# Altium schematic sheet Sheet1.SchDoc
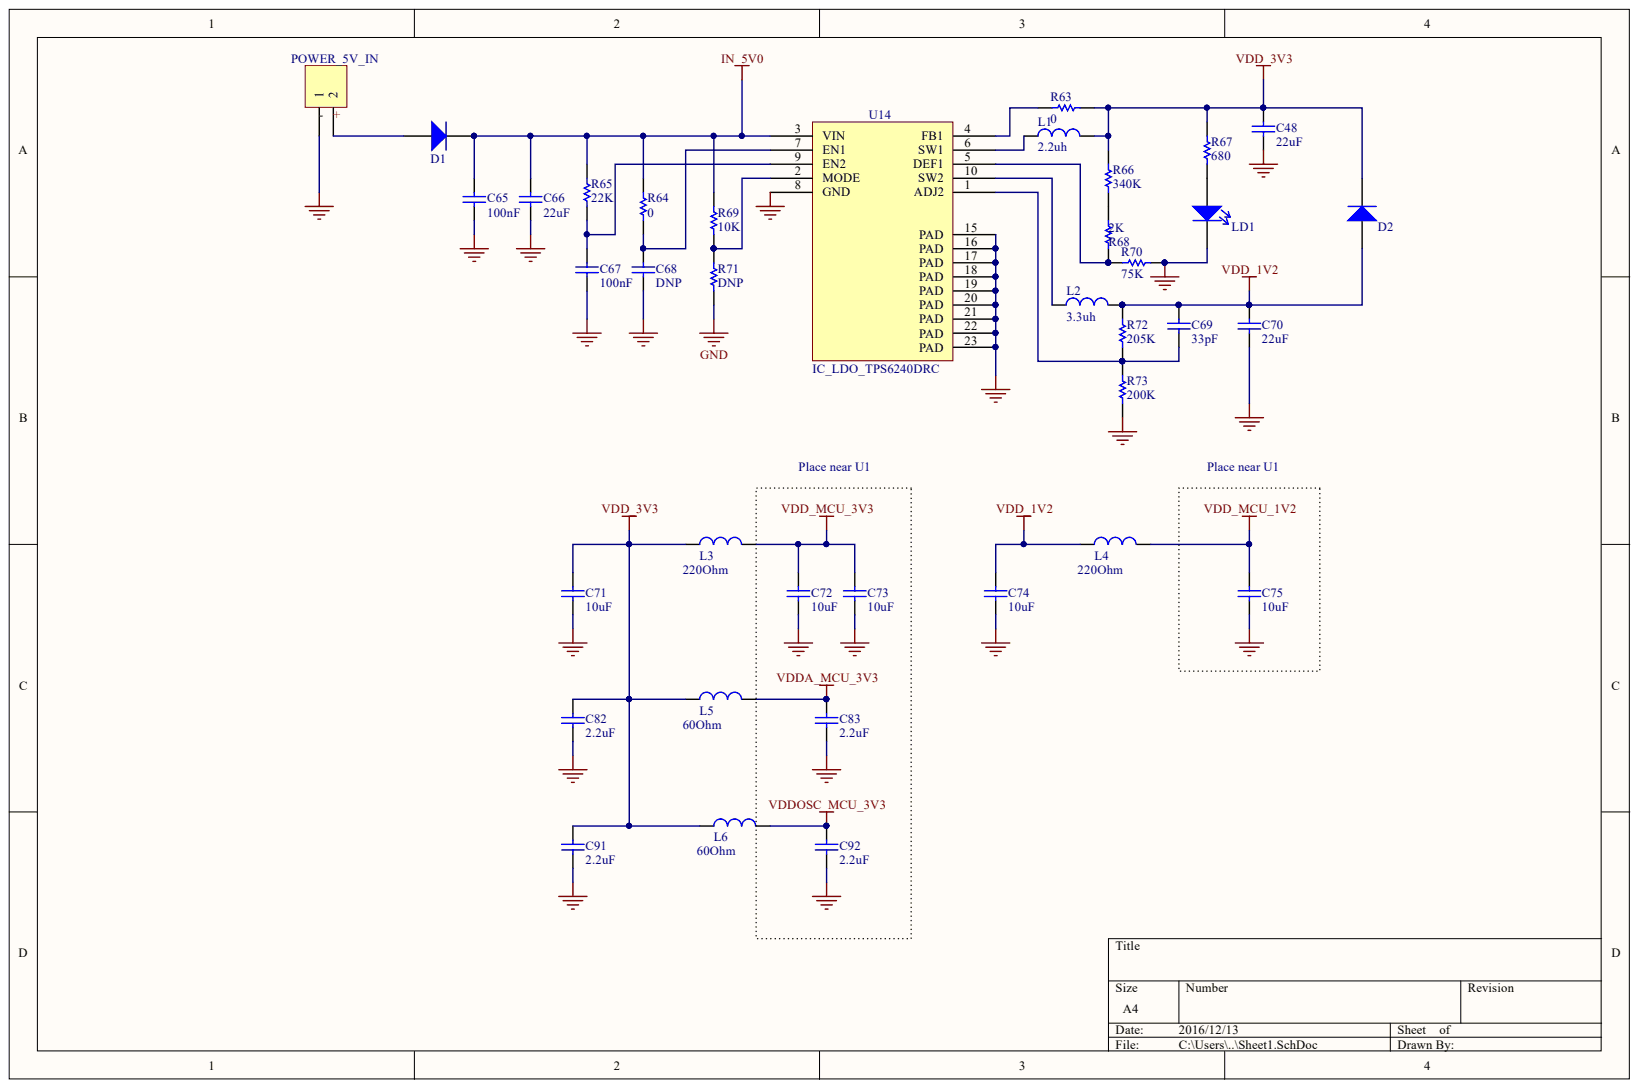
[diagram p0: Sheet1.SchDoc]

## Parts
C48  value 22uF  [0603_TW]  (hidden comment: C_0603)
C65  value 100nF  [0805_TW]  (hidden comment: C_0805)
C66  value 22uF  [0805_TW]  (hidden comment: C_0805)
C67  value 100nF  [0805_TW]  (hidden comment: C_0805)
C68  value DNP  [0805_TW]  (hidden comment: C_0805)
C69  value 33pF  [0805_TW]  (hidden comment: C_0805)
C70  value 22uF  [0805_TW]  (hidden comment: C_0805)
C71  value 10uF  [0805_TW]  (hidden comment: C_0805)
C72  value 10uF  [0805_TW]  (hidden comment: C_0805)
C73  value 10uF  [0805_TW]  (hidden comment: C_0805)
C74  value 10uF  [0805_TW]  (hidden comment: C_0805)
C75  value 10uF  [0805_TW]  (hidden comment: C_0805)
C82  value 2.2uF  [0805_TW]  (hidden comment: C_0805)
C83  value 2.2uF  [0805_TW]  (hidden comment: C_0805)
C91  value 2.2uF  [0805_TW]  (hidden comment: C_0805)
C92  value 2.2uF  [0805_TW]  (hidden comment: C_0805)
D1  DIODE_SOD123  [SOD-123(1206)]  (value not drawn)
D2  DIODE_SOD123  [SOD-123(1206)]  (value not drawn)
IC_LDO_TPS6240DRC  U14
L1  value 2.2uh  [L-SMD-7]  (hidden comment: L_SMD_7)
L2  value 3.3uh  [L-SMD-7]  (hidden comment: L_SMD_7)
L3  value 220Ohm  [0603_TW]  (hidden comment: L_0603)
L4  value 220Ohm  [0603_TW]  (hidden comment: L_0603)
L5  value 60Ohm  [0603_TW]  (hidden comment: L_0603)
L6  value 60Ohm  [0603_TW]  (hidden comment: L_0603)
LD1  LED_Lights  [LED-0805_TW]  (value not drawn)
POWER_5V_IN  Header 2  [HDR1X2]  (value not drawn)
R63  value 0  [0402_TW]  (hidden comment: R_0402)
R64  value 0  [0402_TW]  (hidden comment: R_0402)
R65  value 22K  [0402_TW]  (hidden comment: R_0402)
R66  value 340K  [0402_TW]  (hidden comment: R_0402)
R67  value 680  [0402_TW]  (hidden comment: R_0402)
R68  value 2K  [0402_TW]  (hidden comment: R_0402)
R69  value 10K  [0402_TW]  (hidden comment: R_0402)
R70  value 75K  [0402_TW]  (hidden comment: R_0402)
R71  value DNP  [0402_TW]  (hidden comment: R_0402)
R72  value 205K  [0402_TW]  (hidden comment: R_0402)
R73  value 200K  [0402_TW]  (hidden comment: R_0402)

## Nets
GND: C48.1 C65.1 C66.1 C67.1 C68.1 C70.1 C71.1 C72.1 C73.1 C74.1 C75.1 C82.1 C83.1 C91.1 C92.1 IC_LDO_TPS6240DRC.8(GND) IC_LDO_TPS6240DRC.15(PAD) IC_LDO_TPS6240DRC.16(PAD) IC_LDO_TPS6240DRC.17(PAD) IC_LDO_TPS6240DRC.18(PAD) IC_LDO_TPS6240DRC.19(PAD) IC_LDO_TPS6240DRC.20(PAD) IC_LDO_TPS6240DRC.21(PAD) IC_LDO_TPS6240DRC.22(PAD) IC_LDO_TPS6240DRC.23(PAD) LD1.2 POWER_5V_IN.1 R70.2 R71.2 R73.2
IN_5V0: C65.2 C66.2 D1.2 IC_LDO_TPS6240DRC.3(VIN) R64.1 R65.1 R69.1
VDD_1V2: C69.2 C70.2 C74.2 D2.1 L2.2 L4.1 R72.2
VDD_3V3: C48.2 C71.2 C82.2 C91.2 D2.2 L1.2 L3.1 L5.1 L6.1 R63.2 R66.2 R67.2
VDD_MCU_1V2: C75.2 L4.2
VDD_MCU_3V3: C72.2 C73.2 L3.2
VDDA_MCU_3V3: C83.2 L5.2
VDDOSC_MCU_3V3: C92.2 L6.2
NetC67_2: C67.2 IC_LDO_TPS6240DRC.9(EN2) R65.2
NetC68_2: C68.2 IC_LDO_TPS6240DRC.7(EN1) R64.2
NetC69_1: C69.1 IC_LDO_TPS6240DRC.1(ADJ2) R72.1 R73.1
NetD1_1: D1.1 POWER_5V_IN.2
NetIC_LDO_TPS6240DRC_2: IC_LDO_TPS6240DRC.2(MODE) R69.2 R71.1
NetIC_LDO_TPS6240DRC_4: IC_LDO_TPS6240DRC.4(FB1) R63.1
NetIC_LDO_TPS6240DRC_5: IC_LDO_TPS6240DRC.5(DEF1) R68.1 R70.1
NetIC_LDO_TPS6240DRC_6: IC_LDO_TPS6240DRC.6(SW1) L1.1
NetIC_LDO_TPS6240DRC_10: IC_LDO_TPS6240DRC.10(SW2) L2.1
NetLD1_1: LD1.1 R67.1
NetR66_1: R66.1 R68.2

## Library data of the parts
IC_LDO_TPS6240DRC = TPS62420DRC: Component Kind: Standard; Library Name: IC_LDO_TPS62420DRC.SchLib
POWER_5V_IN = Header 2: Header, 2-Pin; Component Kind: Standard; LatestRevisionDate: 17-Jul-2002; LatestRevisionNote: Re-released for DXP Platform.; Library Name: Miscellaneous Connectors.IntLib; Signal Integrity: Connector; Publisher: Altium Limited
C48 = C_0603: Component Kind: Standard; Library Name: TW_Lib.SchLib
C65-C75 C82 C83 C91 C92 = C_0805: Component Kind: Standard; Library Name: TW_Lib.SchLib
D1 D2 = DIODE_SOD123: Component Kind: Standard; Library Name: TW_Lib.SchLib
L1 L2 = L_SMD_7: Component Kind: Standard; Library Name: TW_Lib.SchLib
L3-L6 = L_0603: Component Kind: Standard; Library Name: TW_Lib.SchLib
LD1 = LED_Lights: Component Kind: Standard; Library Name: TW_Lib.SchLib
R63-R73 = R_0402: Component Kind: Standard; Library Name: TW_Lib.SchLib
